# Altium schematic sheet LDO.SchDoc(LDO)
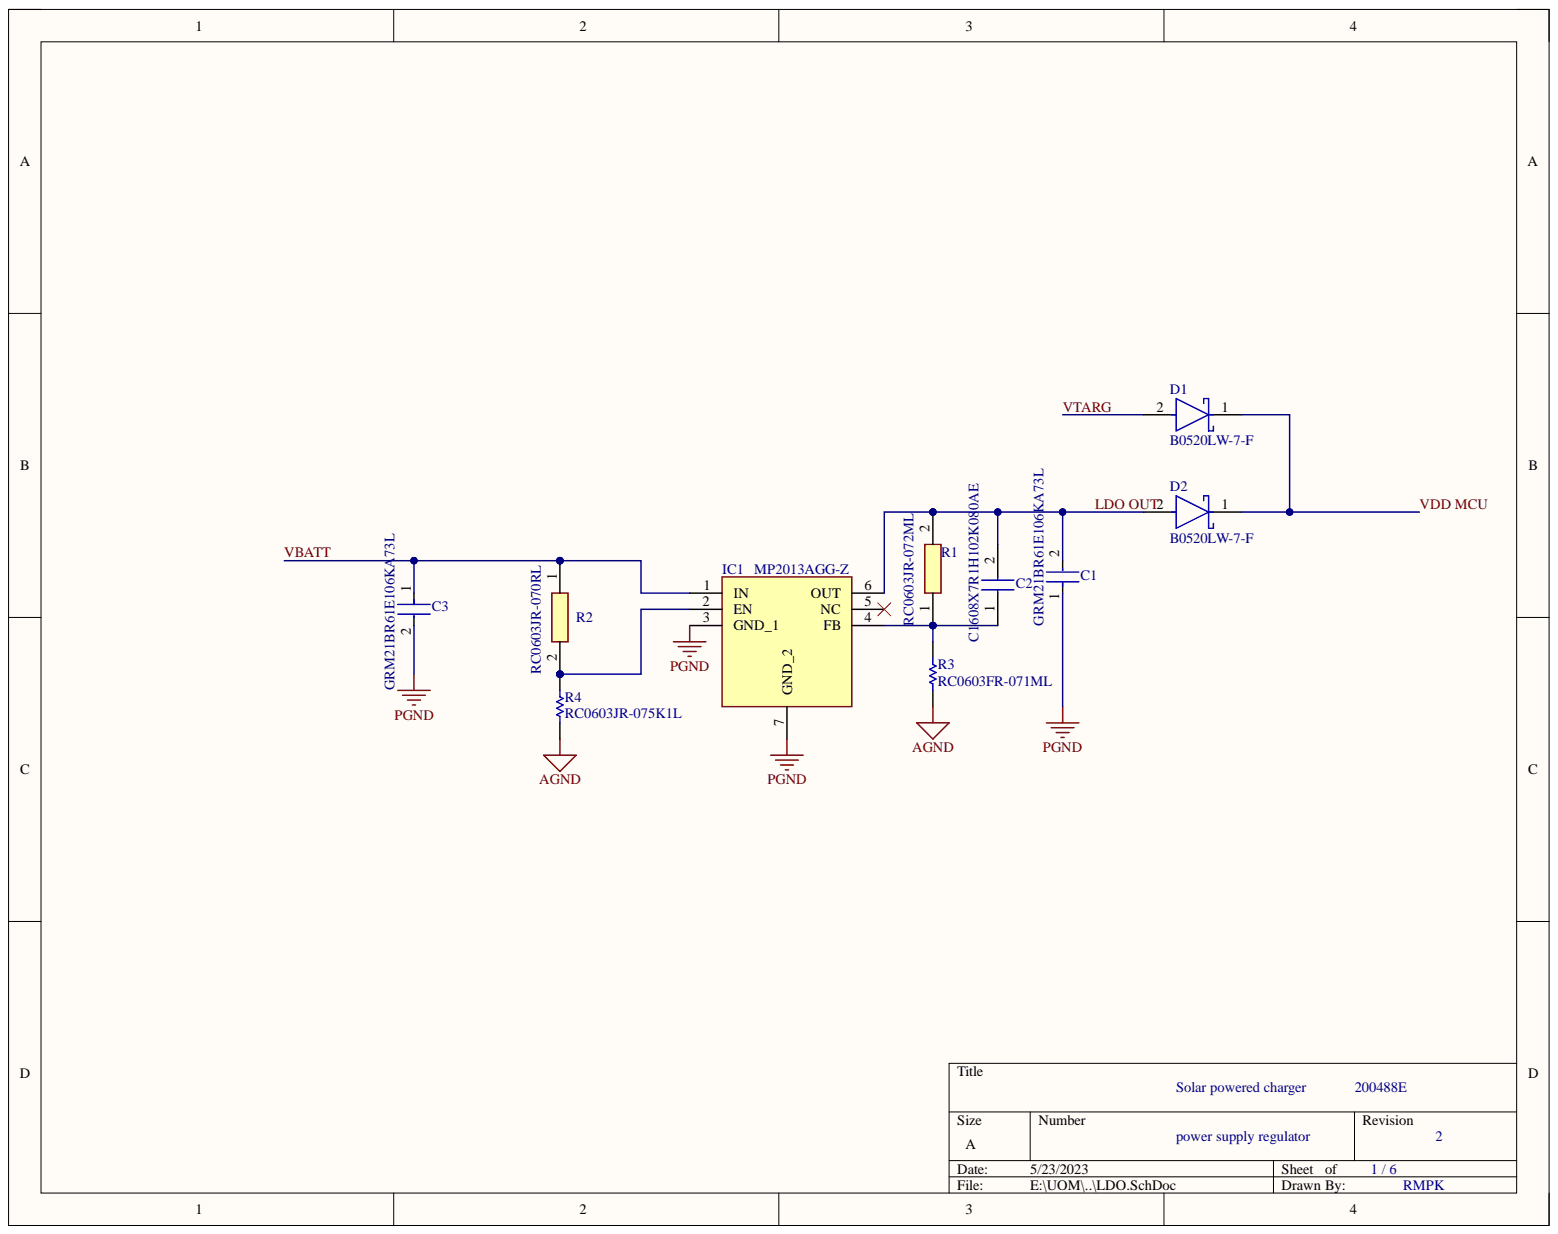
[diagram p0: LDO.SchDoc]

## Parts
C1  GRM21BR61E106KA73L  [FP-GRM21B-0_15-IPC_C]
C2  C1608X7R1H102K080AE  [CAPC1608X80N]
C3  GRM21BR61E106KA73L  [FP-GRM21B-0_15-IPC_C]
D1  B0520LW-7-F  [FP-SOD123-MFG]
D2  B0520LW-7-F  [FP-SOD123-MFG]
IC1  MP2013AGG-Z  [SON65P200X200X100-7N-D]
R1  RC0603JR-072ML  [RESC1608X55N]
R2  RC0603JR-070RL  [RESC1608X55N]
R3  RC0603FR-071ML  [RESC1608X55X25LL10T15]
R4  RC0603JR-075K1L  [RESC1608X55X25LL10T15]

## Nets
GND: R3.1 R4.1
PGND: C1.1 C3.2 IC1.3(GND_1) IC1.7(GND_2)
LDO OUT: C1.2 C2.2 D2.2 IC1.6(OUT) R1.2
VBATT: C3.1 IC1.1(IN) R2.1
VDD MCU: D1.1 D2.1
VTARG: D1.2
NetC2_1: C2.1 IC1.4(FB) R1.1 R3.2
NetIC1_2: IC1.2(EN) R2.2 R4.2

Not connected: IC1 5(NC)

## Library data of the parts
C1 C3 = CMP-2007-03541-2: Chip Multilayer Ceramic Capacitors for General Purpose, 0805, 10uF, X5R, 15%, 10%, 25V; Capacitance: 10uF; Case Code (Imperial): 0805; Case Code (Metric): 2012; Case/Package: 0805; Component Kind: Standard; Depth: 1.25mm; Dielectric: X5R; Height: 1.25mm; Lead Free: Lead Free; Length: 2mm; Library Name: Altium Content Vault; Material: Ceramic; Max Operating Temperature: 85°C; Min Operating Temperature: -55°C; Mount: Surface Mount; Package Quantity: 1; Packaging: Tape and Reel; Radiation Hardening: No; Termination: SMD/SMT; Thickness: 0.053inch; Tolerance: 10%; Voltage: 25V; Voltage Rating: 25V; Voltage Rating (DC): 25V; Width: 0.049inch
C2 = C1608X7R1H102K080AE: Capacitor; Manufacturer_Name: TDK; Arrow Part Number: C1608X7R1H102K080AE; Arrow Price/Stock: https://www.arrow.com/en/products/c1608x7r1h102k080ae/tdk; Component Kind: Standard; Datasheet Link: https://product.tdk.com/info/en/catalog/datasheets/mlcc_commercial_midvoltage_en.pdf; Height: 0.8mm; Manufacturer_Part_Number: C1608X7R1H102K080AE; Mouser Part Number: 810-C1608X7R1H102KAE; Mouser Price/Stock: https://www.mouser.co.uk/ProductDetail/TDK/C1608X7R1H102K080AE?qs=n%2FVzw7c4a0k2XAaPZBtj3A%3D%3D
D1 D2 = CMP-12790-000026-1: DIODE SCHOTTKY 20V 500MA SOD123; Manufacturer: Diodes Inc.; Capacitance: 170pF; Forward Current: 0.5A; Max Junction Temp: +125°C; Max Surge Forward Current: 5.5A; Peak Reverse Current: 250uA; Standoff Height: 0mm; Value: 170pF; Automotive: No; Category: Diode; Component Kind: Standard; ComponentLink2Description: Datasheet; ComponentLink2URL: https://www.diodes.com/assets/Datasheets/ds30051.pdf; Device Class L1: Discrete Semiconductors; Device Class L2: Diodes; Device Class L3: Schottky Diodes; Digikey Description: DIODE SCHOTTKY 20V 500MA SOD123; Footprint Url: https://www.diodes.com/assets/Package-Files/SOD123.pdf; Height: 1.45mm; Ipc Land Pattern Name: SOD365155X123-2; Lead Free: Yes; Library Name: Altium Content Vault; Manufacturer Part Number: B0520LW-7-F; Max Repetitive Reverse Voltage: 20V; Mouser Description: Schottky Diodes & Rectifiers 20V 410mW; Octopart: https://octopart.com/b0520lw-7-f-diodes+inc.-325707; Package: SOD123; Reverse Voltage: 20V; RoHS: TRUE; Temperature: -65°C to +125°C; Temperature Range High: +125°C; Temperature Range Low: -65°C
IC1 = MP2013AGG-Z: Integrated Circuit; Manufacturer_Name: Monolithic Power Systems (MPS); Component Kind: Standard; Datasheet Link: https://www.monolithicpower.com/en/documentview/productdocument/index/version/2/document_type/Datasheet/lang/en/sku/MP2013A/; Height: 1mm; Manufacturer_Part_Number: MP2013AGG-Z; Mouser Part Number: 946-MP2013AGG-Z; Mouser Price/Stock: https://www.mouser.co.uk/ProductDetail/Monolithic-Power-Systems-MPS/MP2013AGG-Z?qs=cslStgdnz9N9a41kZxTVVA%3D%3D
R1 = RC0603JR-072ML: Resistor; Manufacturer_Name: YAGEO; Component Kind: Standard; Datasheet Link: https://www.mouser.com/datasheet/2/447/PYu_RC_Group_51_RoHS_L_11-1984063.pdf; Height: 0.55mm; Manufacturer_Part_Number: RC0603JR-072ML
R2 = RC0603JR-070RL: Resistor; Manufacturer_Name: YAGEO; Arrow Part Number: RC0603JR-070RL; Arrow Price/Stock: https://www.arrow.com/en/products/rc0603jr-070rl/yageo?region=europe; Component Kind: Standard; Datasheet Link: https://componentsearchengine.com/Datasheets/2/RC0603JR-070RL.pdf; Height: 0.55mm; Manufacturer_Part_Number: RC0603JR-070RL; Mouser Part Number: 603-RC0603JR-070RL; Mouser Price/Stock: https://www.mouser.co.uk/ProductDetail/YAGEO/RC0603JR-070RL?qs=2cAdsCoAWRGWyPcuXgGiXQ%3D%3D
R3 = CMP-1659-00056-1: Chip Resistor, 1 MOhm, +/- 1%, 100 mW, -55 to 155 degC, 0603 (1608 Metric), RoHS, Tape and Reel; Capacitance: 1 mF; Case\Package: Radial; Tolerance: 20%; Voltage Rating: 25 V; Component Kind: Standard; ComponentLink1Description: Manufacturer URL; ComponentLink1URL: http://www.yageo.com/; ComponentLink2Description: Datasheet; ComponentLink2URL: http://www.yageo.com/documents/recent/PYu-RC_Group_51_RoHS_L_04.pdf; DatasheetVersion: Rev. 4, 02/2016; Diameter: 10 mm; Height: 20 mm; Lead Pitch: 5 mm; Length: 20 mm; Library Name: Altium Content Vault; Max Operating Temperature: 85 degC; Min Operating Temperature: -40 degC; Mount: Through Hole; Package Quantity: 200; PackageDescription: 2-Pin Surface Mount Device, Body 1.6 x 0.8 mm; PackageReference: 0603; Packaging: Bulk; Radiation Hardening: No; REACH SVHC: No SVHC; RoHSCompliant: true; Voltage Rating (DC): 25 V; Width: 0 inch
R4 = CMP-1012-00091-2: Chip Resistor, 5.1 KOhm, +/- 5%, 0.1 W, -55 to 155 degC, 0603 (1608 Metric), RoHS, Tape and Reel; Manufacturer: Yageo; Power Rating: 100mW; Resistance: 5.1kOhms; Temperature Coefficient: 100PPM/C; Voltage Rating: 50V; Case Code - in: 0603; Case Code - mm: 1608; Component Kind: Standard; Datasheet URL: http://www.yageo.com/documents/recent/PYu-RC_Group_51_RoHS_L_7.pdf; Datasheet Version: Rev. 7, 05/2017; Library Name: Altium Content Vault; Manufacturer URL: http://www.yageo.com/; Mounting Technology: SurfaceMount; Operating Temperature Range: -55Cto+155C; Package Description: 2-Pin Surface Mount Device, Body 1.6 x 0.8 mm; Package Reference: 0603; Packaging: Reel; Product: ThickFilmResistorsGeneralPurpose; Series: RC; Termination Style: SMD/SMT; Tolerance: 5%
